# Altium schematic sheet POWER.SchDoc
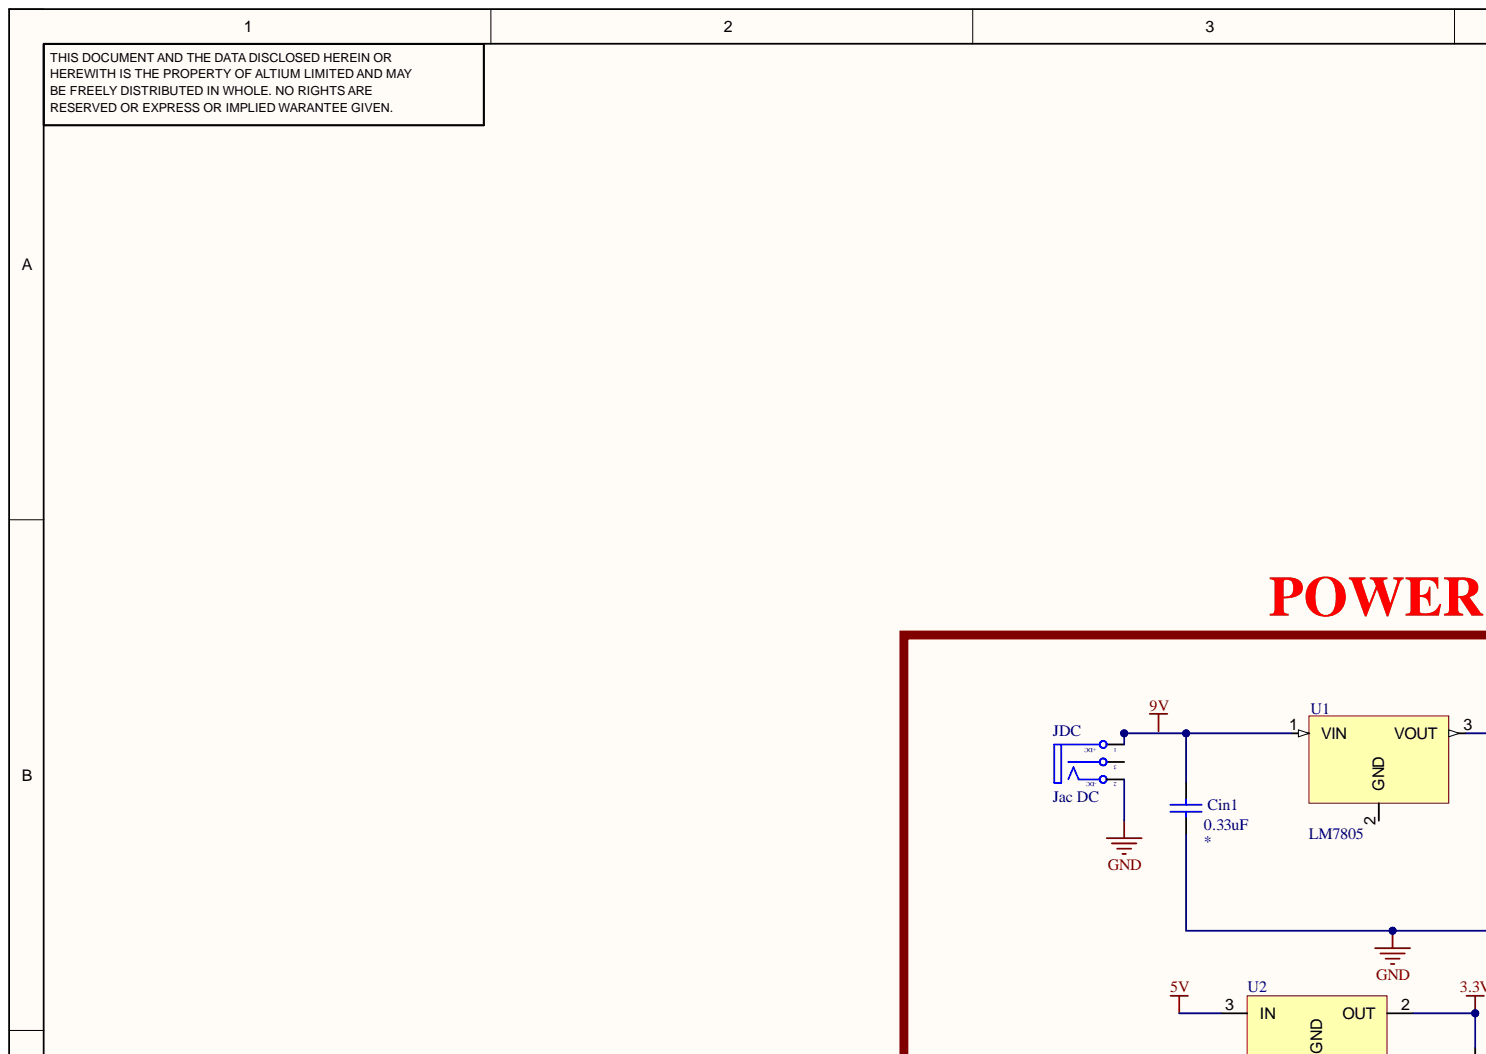
[diagram p0: POWER.SchDoc, top left]
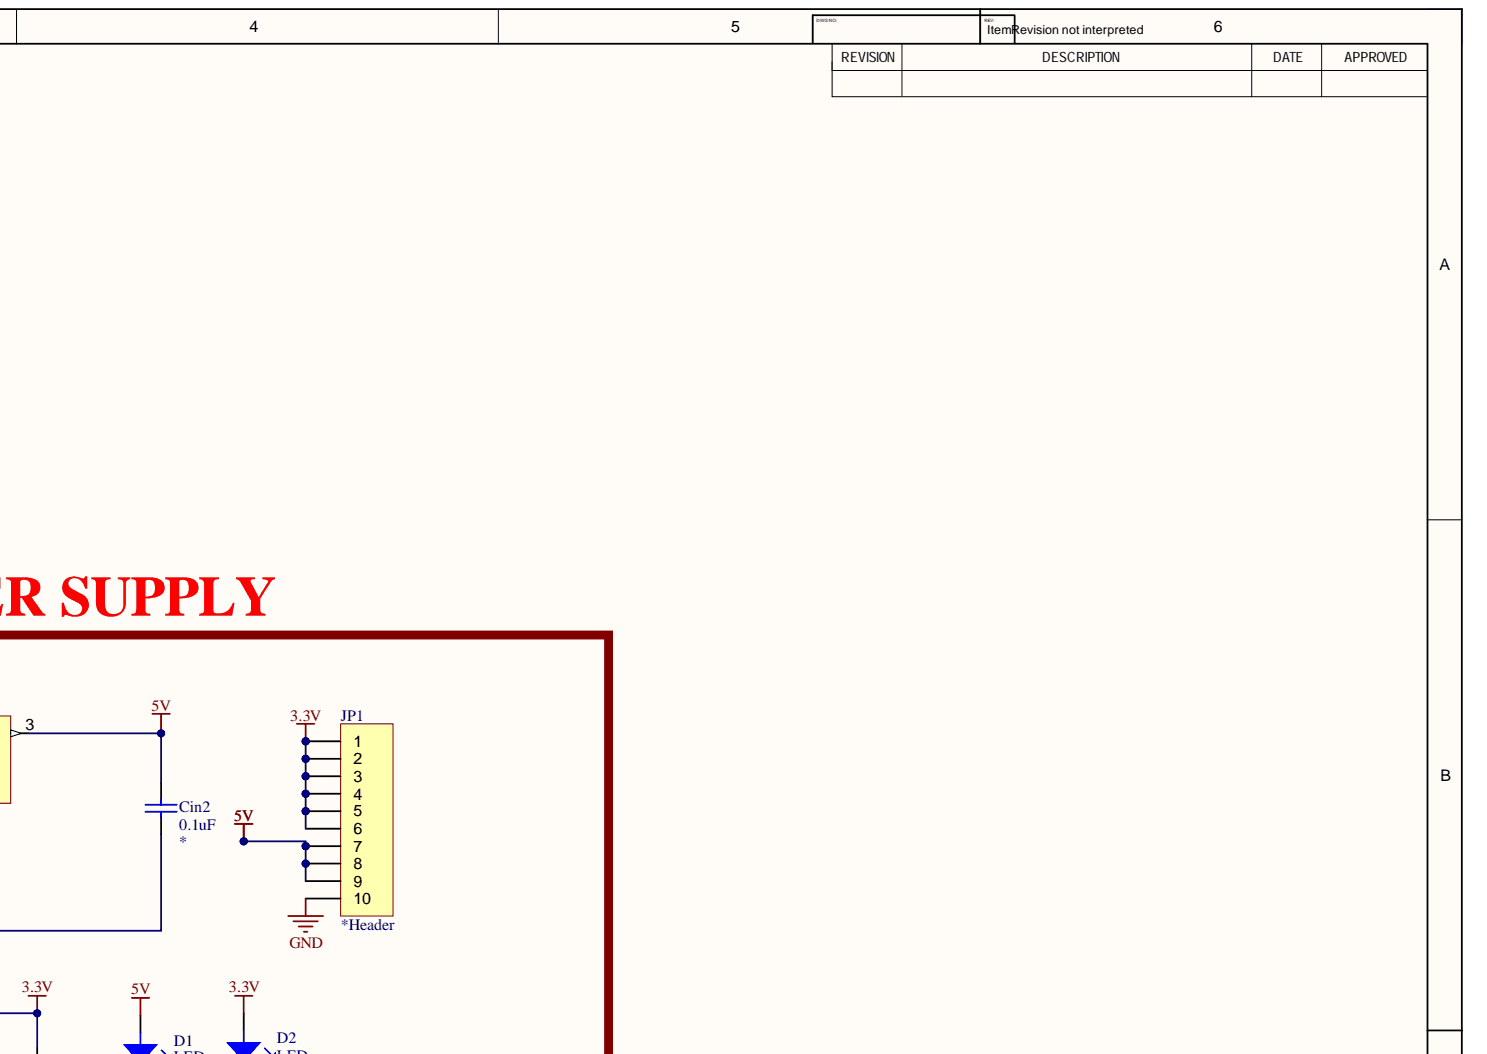
[diagram p1: POWER.SchDoc, top right]
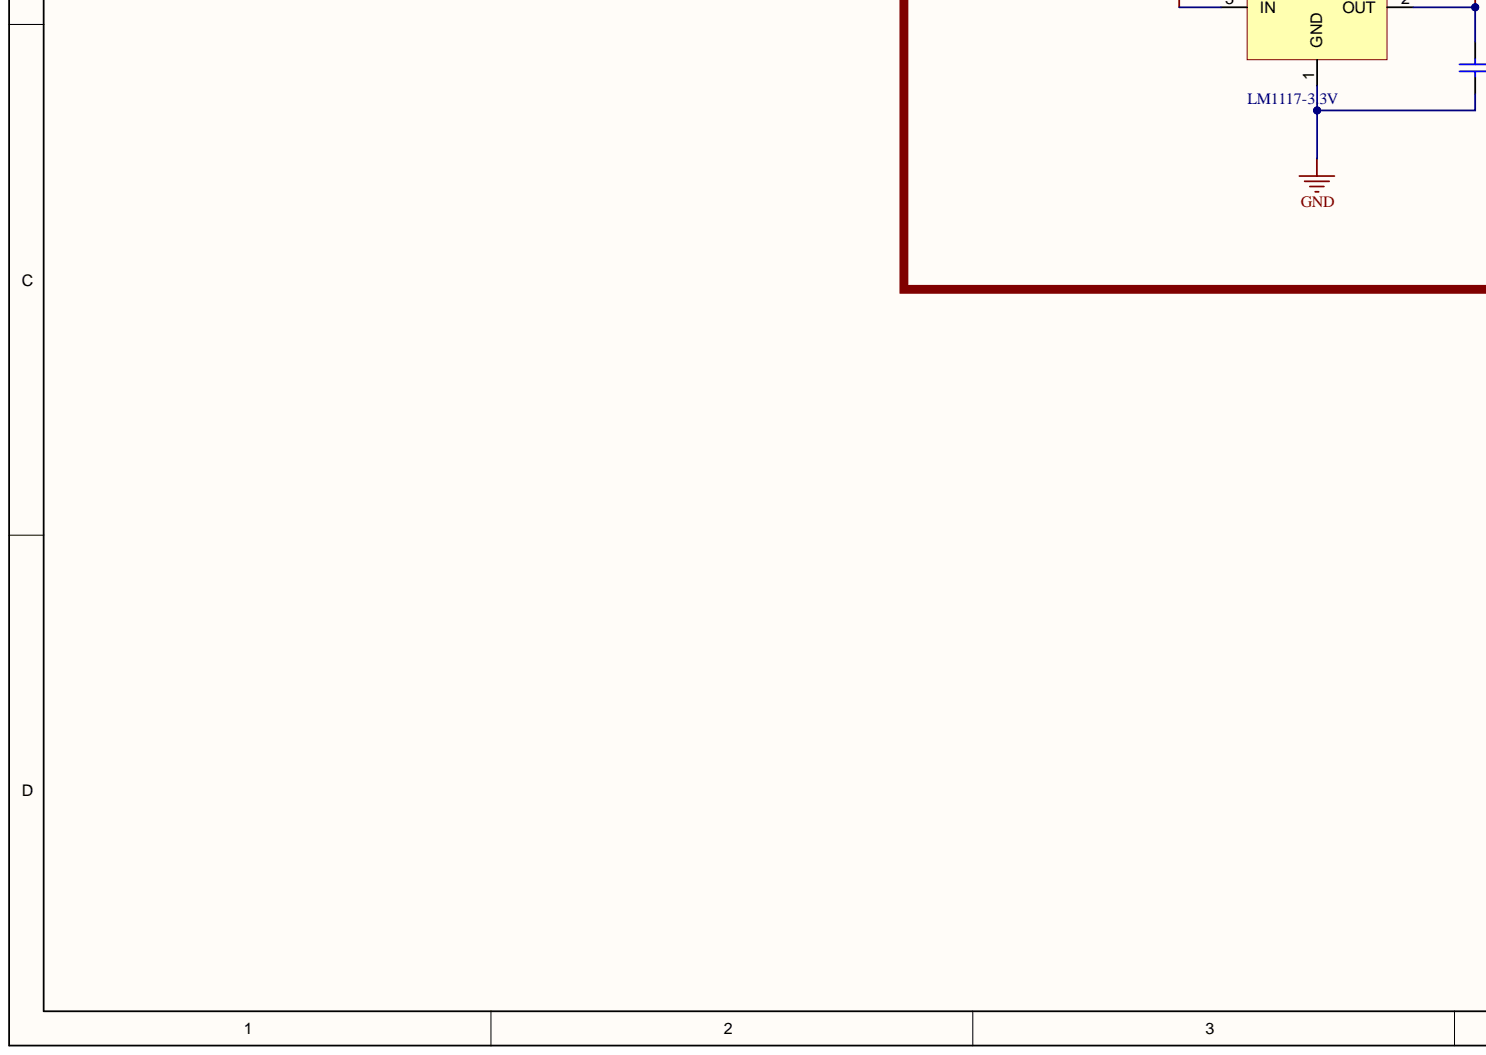
[diagram p2: POWER.SchDoc, bottom left]
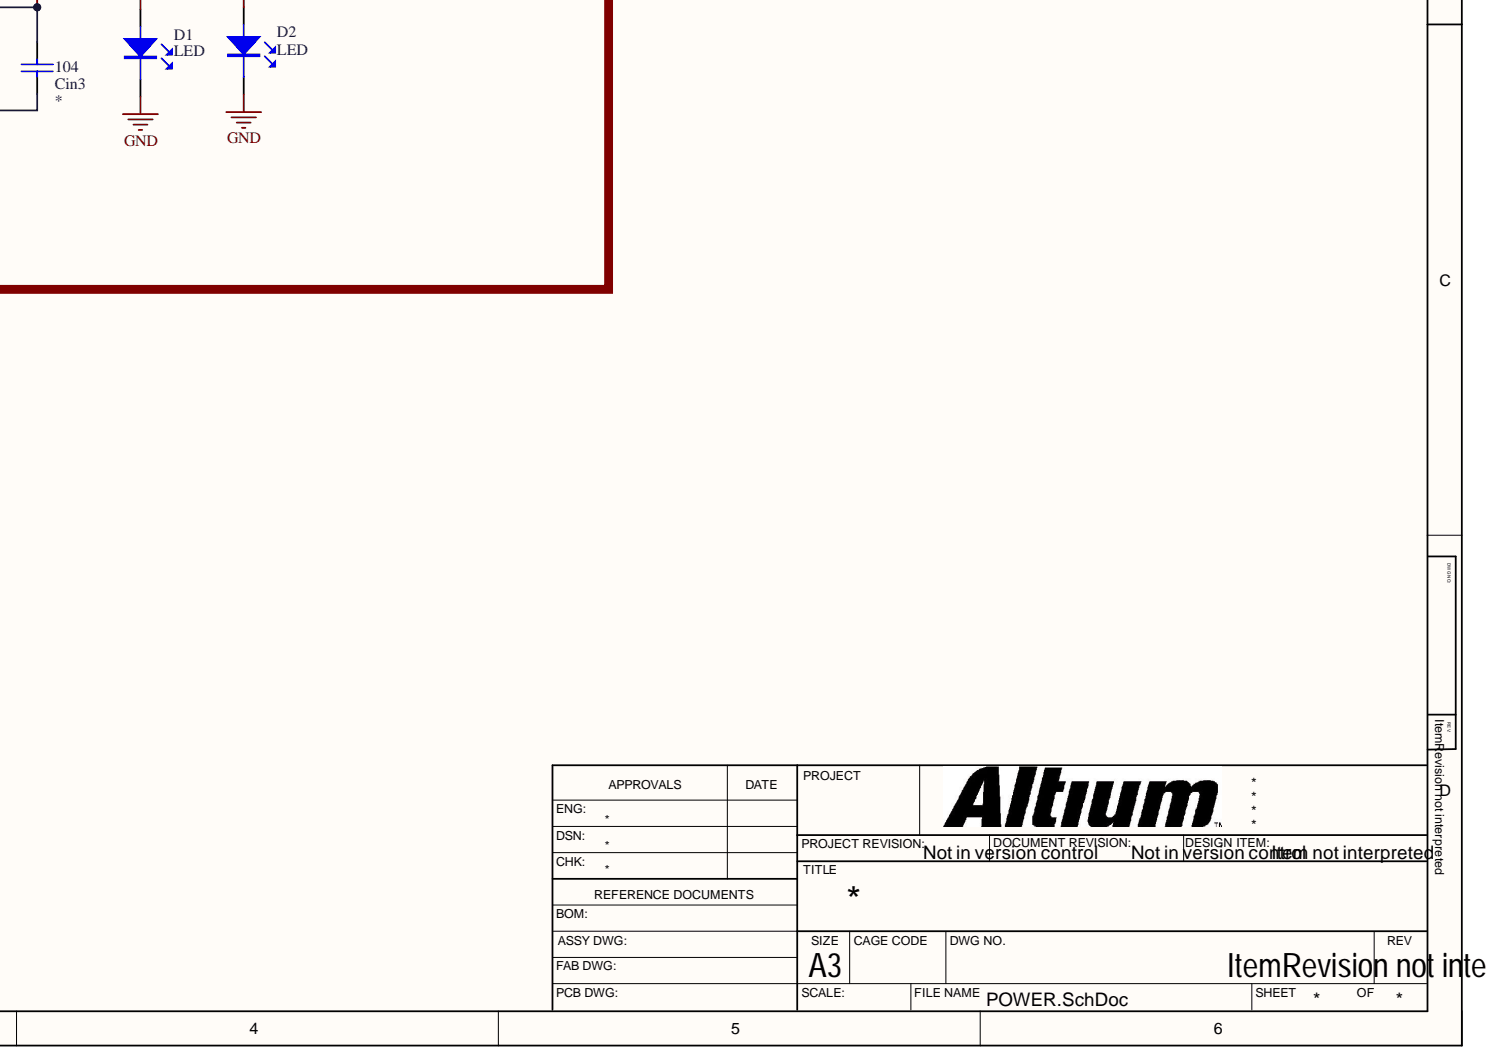
[diagram p3: POWER.SchDoc, bottom right]

## Parts
104  Cin3
Cin1  0.33uF
Cin2  0.1uF
D1  LED  [CAP10UF]
D2  LED  [CAP10UF]
JDC  Jac DC  [DC-IN]
JP1  *Header  [HDR1X10]
U1  LM7805  [TO-220]
U2  LM1117-3.3V  [SOT223-4N]

## Nets
3.3V: 104.1 D2.1 JP1.1 JP1.2 JP1.3 JP1.4 JP1.5 JP1.6 U2.2
5V: Cin2.1 D1.1 JP1.7 JP1.8 JP1.9 U1.3 U2.3
GND: 104.2 Cin1.2 Cin2.2 D1.2 D2.2 JDC.2 JP1.10 U2.1
NetCin1_1: Cin1.1 JDC.1 U1.1
9V:

Not connected: JDC 3; U1 2

## Library data of the parts
104 Cin1 Cin2 = CAP: Capacitor; Component Kind: Standard; Library Name: Cty1.SchLib
JDC = DCIN: Jac DC input; Component Kind: Standard; Library Name: Cty1.SchLib; Value: Jac DC
JP1 = Header 10: Header, 10-Pin, Right Angle; Component Kind: Standard; Library Name: Cty1.SchLib
U1 = LM7805: TO-220; Text Field1: 70; Text Field2: 30; Component Kind: Standard; Library Name: Cty1.SchLib
U2 = LM1117: 800mA Low-Dropout Linear Regulator; Component Kind: Standard; Library Name: Cty1.SchLib; Website: http://group3i.net; Creator: Nguyen Ba Bien
D1 D2 = LED: Component Kind: Standard; Library Name: Cty1.SchLib
